# Altium schematic sheet nanoCH32V305.SchDoc
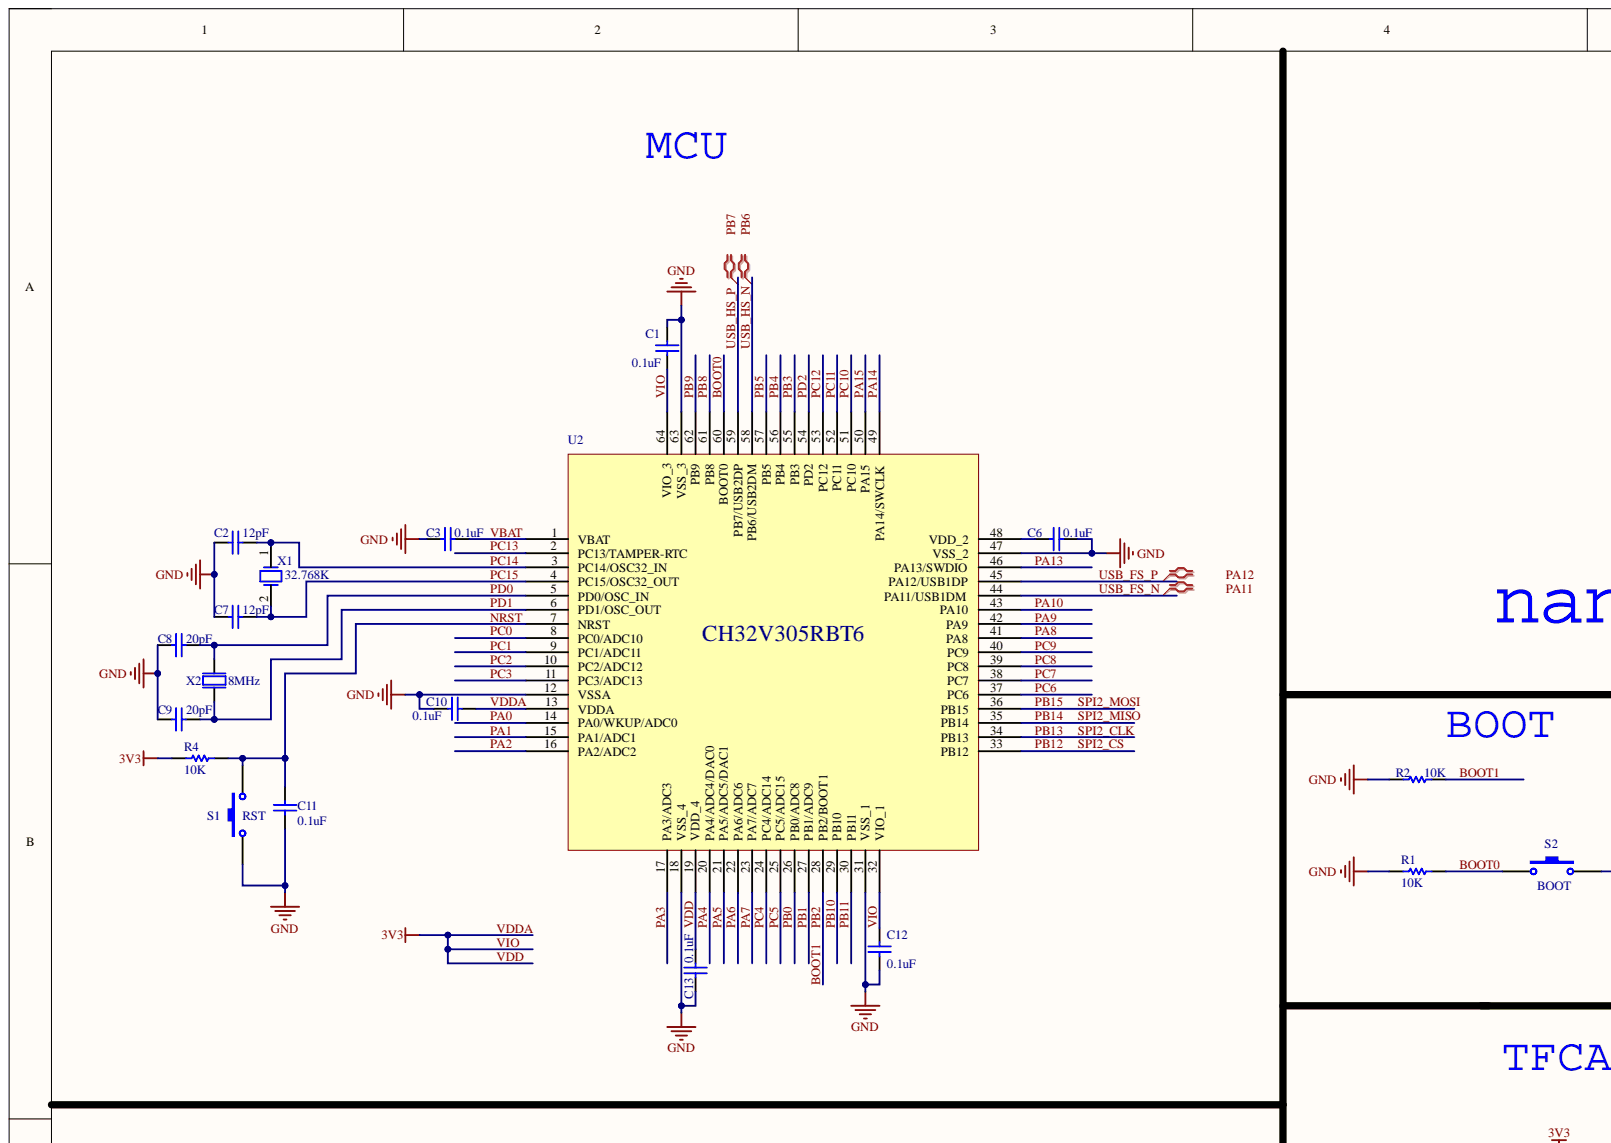
[diagram p0: nanoCH32V305.SchDoc, top left]
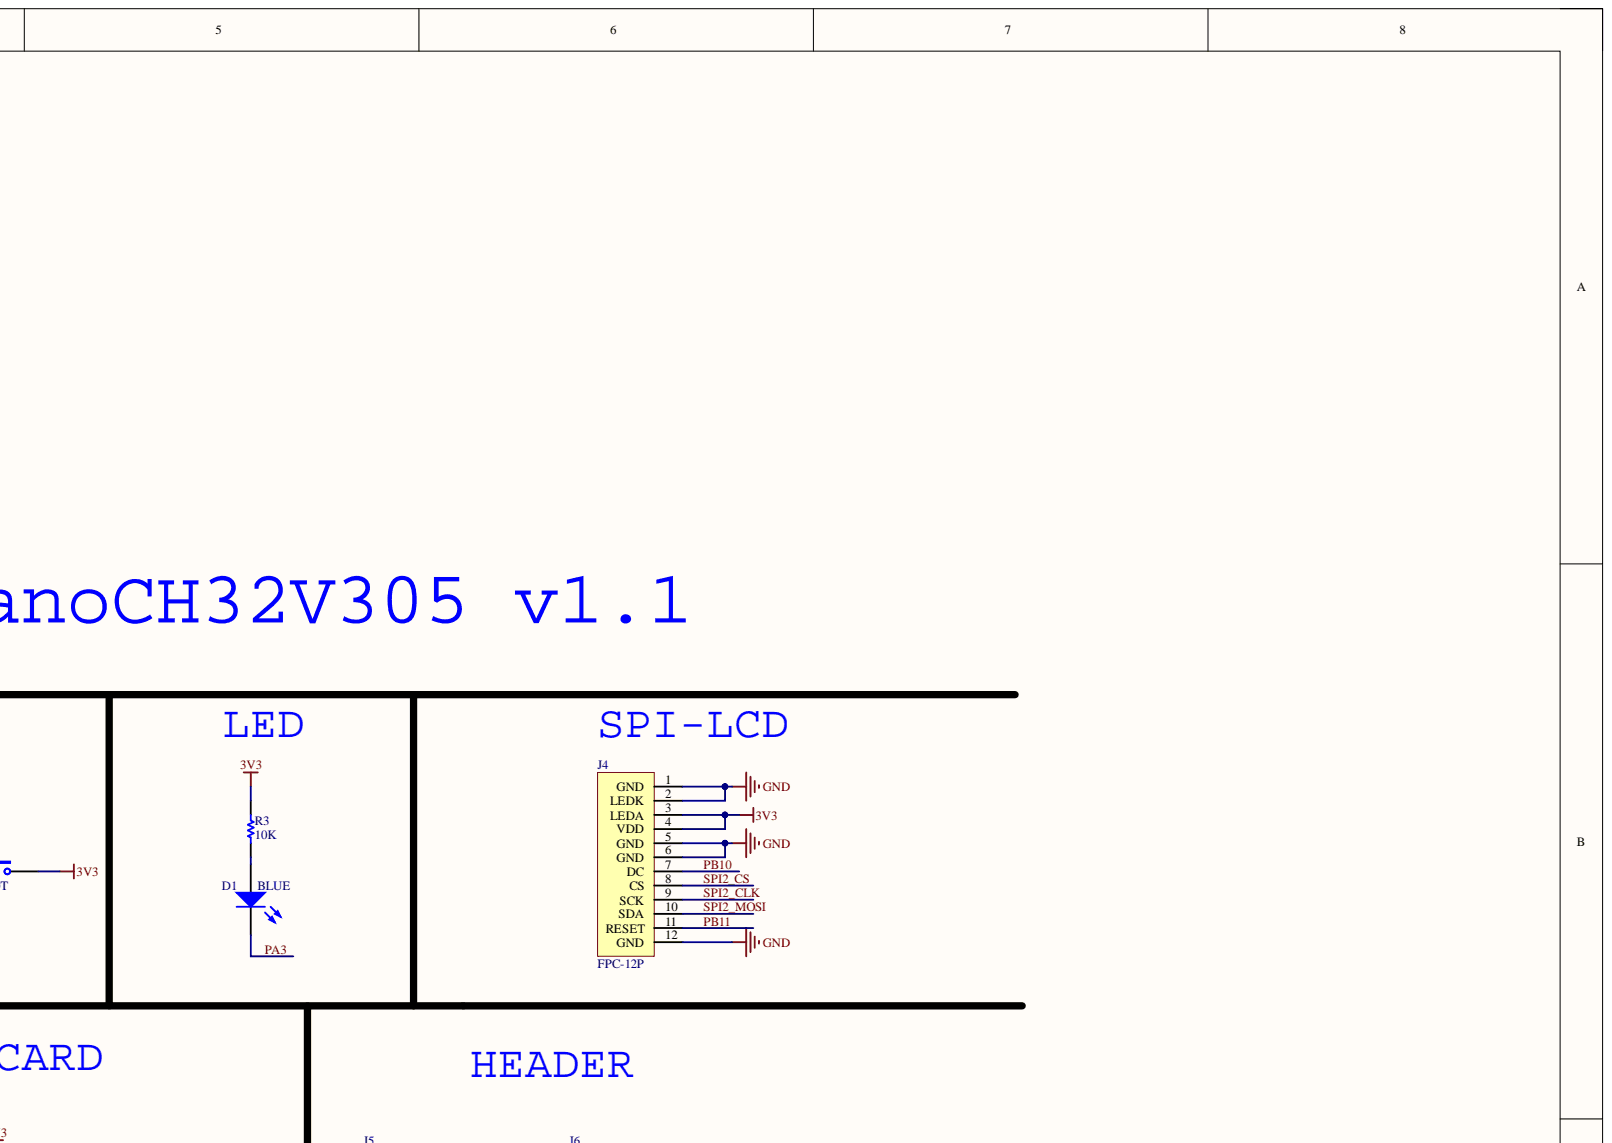
[diagram p1: nanoCH32V305.SchDoc, top right]
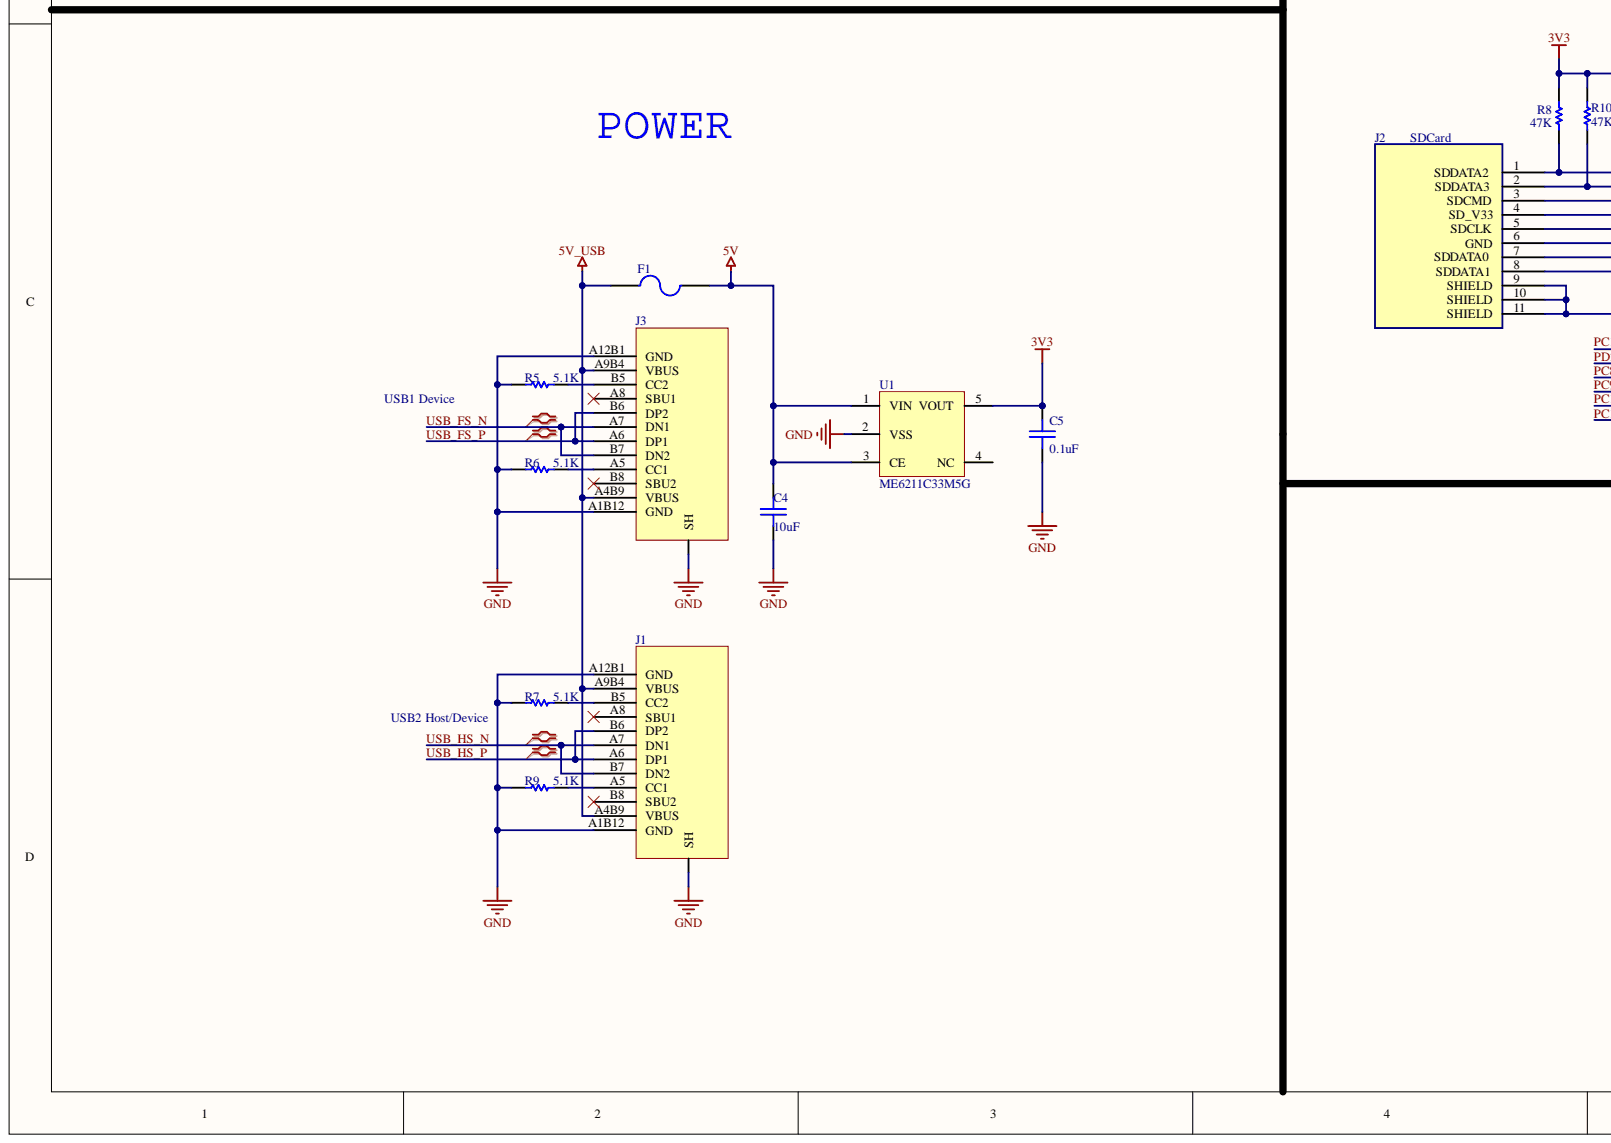
[diagram p2: nanoCH32V305.SchDoc, bottom left]
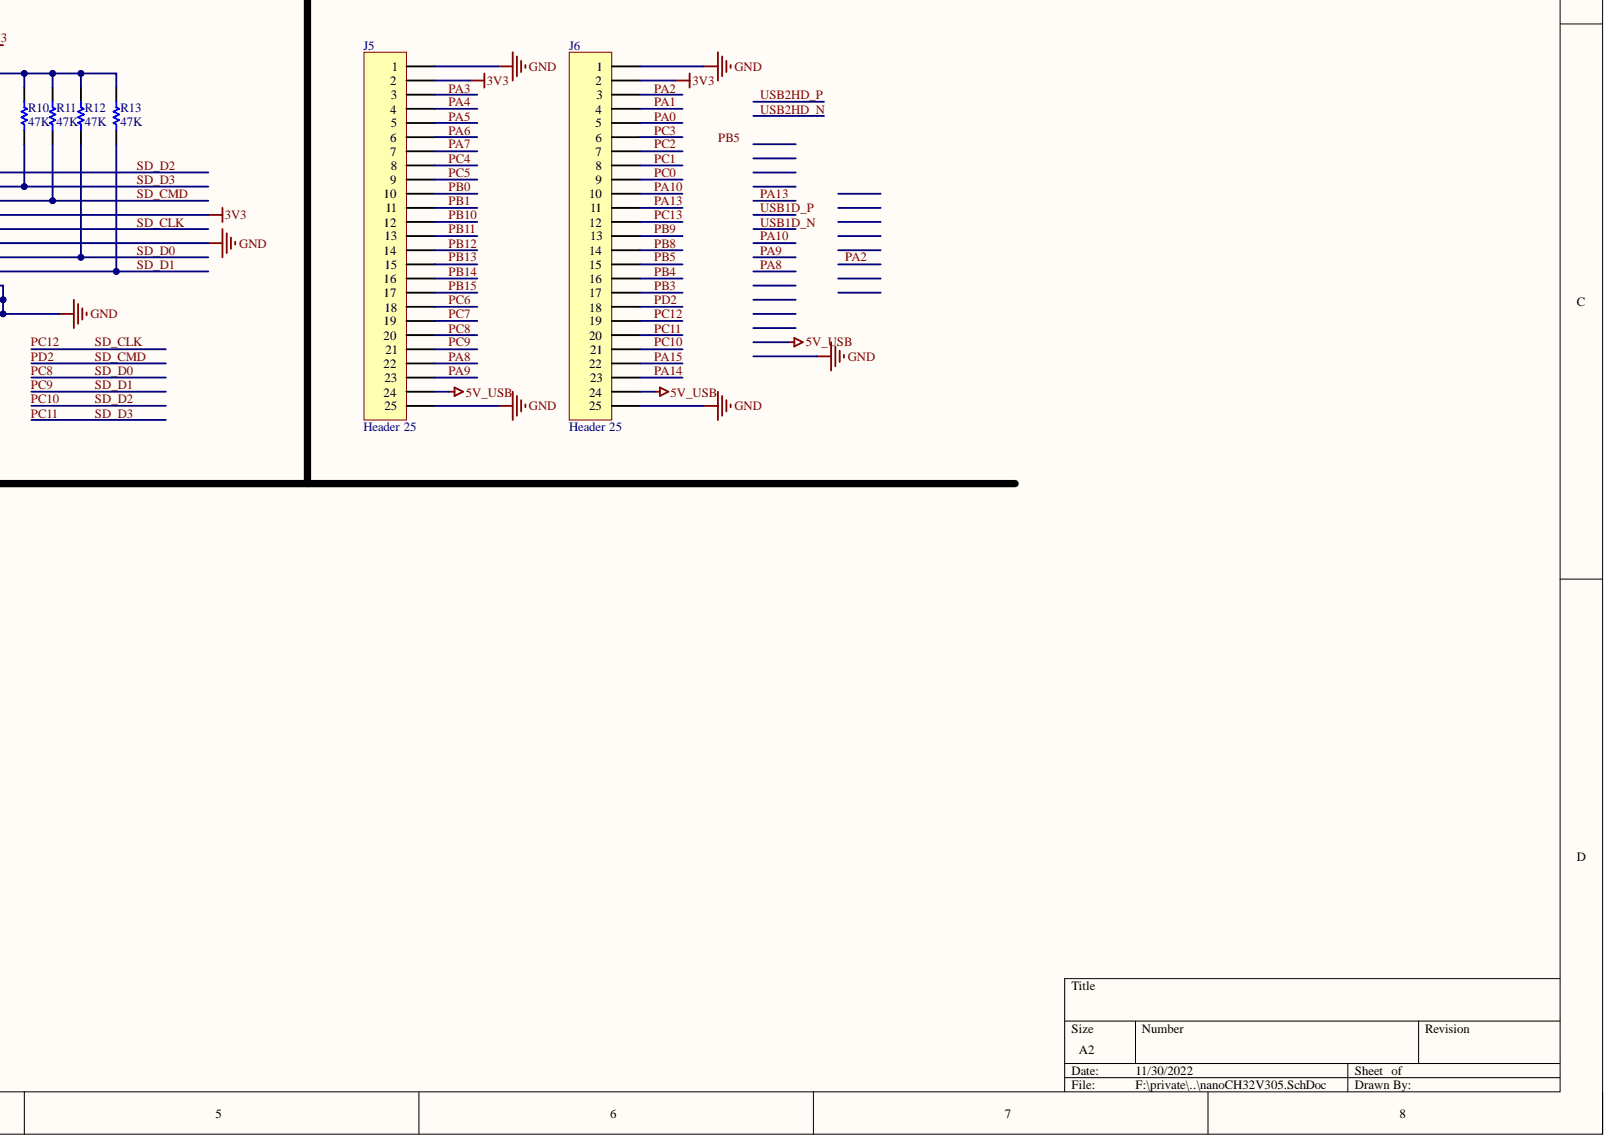
[diagram p3: nanoCH32V305.SchDoc, bottom right]

## Parts
C1  0.1uF  [C 0402_M_new]
C2  12pF  [C 0402_M_new]
C3  0.1uF  [C 0402_M_new]
C4  10uF  [C 0402_M_new]
C5  0.1uF  [C 0402_M_new]
C6  0.1uF  [C 0402_M_new]
C7  12pF  [C 0402_M_new]
C8  20pF  [C 0402_M_new]
C9  20pF  [C 0402_M_new]
C10  0.1uF  [C 0402_M_new]
C11  0.1uF  [C 0402_M_new]
C12  0.1uF  [C 0402_M_new]
C13  0.1uF  [C 0402_M_new]
D1  BLUE  [0603_LED_B]
F1  Fuse 2  [FU 0805]  (value not drawn)
J1  Type_C_16P  [Type_C_16P]  (value not drawn)
J2  SDCard  [SDCARD-1]
J3  Type_C_16P  [Type_C_16P]  (value not drawn)
J4  FPC-12P  [FPC0.5 2H-WS-12P]
J5  Header 25  [HEADER_25x1]
J6  Header 25  [HEADER_25x1]
R1  10K  [R 0402_M_new]
R2  10K  [R 0402_M_new]
R3  10K  [R 0402_M_new]
R4  10K  [R 0402_M_new]
R5  5.1K  [R 0402_M_new]
R6  5.1K  [R 0402_M_new]
R7  5.1K  [R 0402_M_new]
R8  47K  [R 0402_M_new]
R9  5.1K  [R 0402_M_new]
R10  47K  [R 0402_M_new]
R11  47K  [R 0402_M_new]
R12  47K  [R 0402_M_new]
R13  47K  [R 0402_M_new]
S1  RST  [SW-SMD_4P-L4.2-W3.3-P2.15-LS5.1]
S2  BOOT  [SW-SMD_4P-L4.2-W3.3-P2.15-LS5.1]
U1  ME6211C33M5G  [SOT23-5]
U2  CH32V305RBT6  [CH32V305RBT6]
X1  32.768K  [OSC 3215-2P]
X2  8MHz  [BT-XTAL_3225]

## Nets
5V: C4.1 F1.2 U1.1(VIN) U1.3(CE)
5V_USB: F1.1 J1.A4B9(VBUS) J1.A9B4(VBUS) J3.A4B9(VBUS) J3.A9B4(VBUS) J5.24 J6.24
GND: C1.1 C2.2 C3.2 C4.2 C5.2 C6.1 C7.2 C8.2 C9.2 C10.2 C11.1 C12.2 C13.2 J1.0(SH) J1.A1B12(GND) J1.A12B1(GND) J2.6(GND) J2.9(SHIELD) J2.10(SHIELD) J2.11(SHIELD) J3.0(SH) J3.A1B12(GND) J3.A12B1(GND) J4.1(GND) J4.2(LEDK) J4.5(GND) J4.6(GND) J4.12(GND) J5.1 J5.25 J6.1 J6.25 R1.1 R2.2 R5.1 R6.1 R7.1 R9.1 S1.1 U1.2(VSS) U2.12(VSSA) U2.18(VSS_4) U2.31(VSS_1) U2.47(VSS_2) U2.63(VSS_3) X2.2 X2.4
BOOT0: R1.2 S2.1 U2.60(BOOT0)
BOOT1: R2.1 U2.28(PB2/BOOT1)
NRST: C11.2 R4.1 S1.2 U2.7(NRST)
PA0: J6.5 U2.14(PA0/WKUP/ADC0)
PA1: J6.4 U2.15(PA1/ADC1)
PA2: J6.3 U2.16(PA2/ADC2)
PA3: D1.2 J5.3 U2.17(PA3/ADC3)
PA4: J5.4 U2.20(PA4/ADC4/DAC0)
PA5: J5.5 U2.21(PA5/ADC5/DAC1)
PA6: J5.6 U2.22(PA6/ADC6)
PA7: J5.7 U2.23(PA7/ADC7)
PA8: J5.22 U2.41(PA8)
PA9: J5.23 U2.42(PA9)
PA10: J6.10 U2.43(PA10)
PA13: J6.11 U2.46(PA13/SWDIO)
PA14: J6.23 U2.49(PA14/SWCLK)
PA15: J6.22 U2.50(PA15)
PB0: J5.10 U2.26(PB0/ADC8)
PB1: J5.11 U2.27(PB1/ADC9)
PB3: J6.17 U2.55(PB3)
PB4: J6.16 U2.56(PB4)
PB5: J6.15 U2.57(PB5)
PB8: J6.14 U2.61(PB8)
PB9: J6.13 U2.62(PB9)
PB10: J4.7(DC) J5.12 U2.29(PB10)
PB11: J4.11(RESET) J5.13 U2.30(PB11)
PB12: J4.8(CS) J5.14 U2.33(PB12)
PB13: J4.9(SCK) J5.15 U2.34(PB13)
PB14: J5.16 U2.35(PB14)
PB15: J4.10(SDA) J5.17 U2.36(PB15)
PC0: J6.9 U2.8(PC0/ADC10)
PC1: J6.8 U2.9(PC1/ADC11)
PC2: J6.7 U2.10(PC2/ADC12)
PC3: J6.6 U2.11(PC3/ADC13)
PC4: J5.8 U2.24(PC4/ADC14)
PC5: J5.9 U2.25(PC5/ADC15)
PC6: J5.18 U2.37(PC6)
PC7: J5.19 U2.38(PC7)
PC8: J2.7(SDDATA0) J5.20 R12.2 U2.39(PC8)
PC9: J2.8(SDDATA1) J5.21 R13.2 U2.40(PC9)
PC10: J2.1(SDDATA2) J6.21 R8.2 U2.51(PC10)
PC11: J2.2(SDDATA3) J6.20 R10.2 U2.52(PC11)
PC12: J2.5(SDCLK) J6.19 U2.53(PC12)
PC13: J6.12 U2.2(PC13/TAMPER-RTC)
PC14: C2.1 U2.3(PC14/OSC32_IN) X1.1
PC15: C7.1 U2.4(PC15/OSC32_OUT) X1.2
PD0: C8.1 U2.5(PD0/OSC_IN) X2.1
PD1: C9.1 U2.6(PD1/OSC_OUT) X2.3
PD2: J2.3(SDCMD) J6.18 R11.2 U2.54(PD2)
USB_FS_N: J3.A7(DN1) J3.B7(DN2) U2.44(PA11/USB1DM)
USB_FS_P: J3.A6(DP1) J3.B6(DP2) U2.45(PA12/USB1DP)
USB_HS_N: J1.A7(DN1) J1.B7(DN2) U2.58(PB6/USB2DM)
USB_HS_P: J1.A6(DP1) J1.B6(DP2) U2.59(PB7/USB2DP)
VBAT: C3.1 U2.1(VBAT)
VDD: C1.2 C5.1 C10.1 C12.1 C13.1 J2.4(SD_V33) J4.3(LEDA) J4.4(VDD) J5.2 J6.2 R3.1 R4.2 R8.1 R10.1 R11.1 R12.1 R13.1 S2.2 U1.5(VOUT) U2.13(VDDA) U2.19(VDD_4) U2.32(VIO_1) U2.64(VIO_3)
NetC6_2: C6.2 U2.48(VDD_2)
NetD1_1: D1.1 R3.2
NetJ1_A5: J1.A5(CC1) R9.2
NetJ1_B5: J1.B5(CC2) R7.2
NetJ3_A5: J3.A5(CC1) R6.2
NetJ3_B5: J3.B5(CC2) R5.2
PA11:
PA12:
PB6:
PB7:
USB1D_N:
USB1D_P:
USB2HD_N:
USB2HD_P:

Not connected: J1 A8(SBU1) B8(SBU2); J3 A8(SBU1) B8(SBU2); U1 4(NC)

## Library data of the parts
C1-C3 C6-C13 = C0603: Capacitor; Component Kind: Standard; LatestRevisionDate: 17-Jul-2002; LatestRevisionNote: Re-released for DXP Platform.; Library Name: MYLIB.IntLib; PackageDescription: Radial Cap, Thru-Hole; 2 Leads; 0.3 in Pin Spacing; PackageReference: RAD-0.3; Published: 8-Jun-2000; Signal Integrity: Cap; Simulation: CAP; Publisher: Altium Limited
  C1 C3 C6 C10-C13: Value: 0.1uF
  C2 C7: Value: 12pF
  C8 C9: Value: 20pF
C4 = SMT_C_0805: Surface mount capacitor 0805; Component Kind: Standard; Library Name: Vowstar_Basic_Device.IntLib
C5 = SMT_C_0402: Surface mount capacitor 0402; Component Kind: Standard; Library Name: Vowstar_Basic_Device.IntLib
D1 = D0603: Typical RED, GREEN, YELLOW, AMBER GaAs LED; Component Kind: Standard; LatestRevisionDate: 17-Jul-2002; LatestRevisionNote: Re-released for DXP Platform.; Library Name: MYLIB.IntLib; PackageDescription: SM LED; 2 Flat Leads; PackageReference: 3.2X1.6X1.1; PackageVersion: Feb-2002; Published: 8-Jun-2000; Simulation: LED2; Publisher: Altium Limited
F1 = Fuse 2: Fuse; Component Kind: Standard; LatestRevisionDate: 17-Jul-2002; LatestRevisionNote: Re-released for DXP Platform.; Library Name: Miscellaneous Devices.IntLib; PackageDescription: Thru-Hole; 2 Leads; PackageReference: PIN-W2/E2.8; Published: 8-Jun-2000; Simulation: FUSE; Publisher: Altium Limited
R1-R13 = R0603: Resistor; Component Kind: Standard; LatestRevisionDate: 17-Jul-2002; LatestRevisionNote: Re-released for DXP Platform.; Library Name: MYLIB.IntLib; PackageDescription: Axial Device, Thru-Hole; 2 Leads; 0.3 in Pin Spacing; PackageReference: AXIAL-0.3; Published: 8-Jun-2000; Signal Integrity: Res; Simulation: RESISTOR; Publisher: Altium Limited
  R1-R4: Value: 10K
  R5-R7 R9: Value: 5.1K
  R8 R10-R13: Value: 47K
S1 S2 = SW-PB: Switch; Component Kind: Standard; LatestRevisionDate: 17-Jul-2002; LatestRevisionNote: Re-released for DXP Platform.; Library Name: Miscellaneous Devices.IntLib; PackageDescription: Switch; 2 Leads; PackageReference: SPST-2; Published: 24-Mar-1999; Publisher: Altium Limited
X1 = XTAL: Component Kind: Standard; Library Name: nanoCH32V103.SCHLIB
X2 = XTAL SMD 12MHz (3225): XTAL SMD 3225, 8MHz, 18pF, ±30ppm; 2nd source part number: 184-2056; Manufacturer: TXC Corporation; Manufacturer Part Number: 7M-12.000MAAJ-T; Artikkelnr Noca: 22019166; Artikkelnr Simpro: N.A.; Database Table Name: Crystal_Osc$; Note: XTAL SMD 12.000MHz; 2nd source Supplier: Farnell; Component Kind: Standard; Datasheet: http://www.txccrystal.com/images/pdf/7m.pdf; Footprint Doc: BT-XTAL_3225; LatestRevisionDate: 2010-08-31; Library Name: Nordic Discrete DB.DbLib; Part Number: XTAL SMD 12MHz (3225); Pin Count: 4; Published: 2010-08-31; Supplier: Digi-Key; Supplier part number: 887-1121-1-ND; Value: 8MHz; Publisher: Nordic Semiconductor
J1 J3 = Type_C_16P: Component Kind: Standard; Library Name: ICESugar.SCHLIB
J2 = SDCard: Component Kind: Standard; Library Name: ICESugar-Pro.SCHLIB
J4 = FPC-12P: Component Kind: Standard; Library Name: nanoCH32V203.SCHLIB
J5 J6 = Header 25: Component Kind: Standard; Library Name: nanoCH32V305.SCHLIB
U1 = ME6211C33M5G: Component Kind: Standard
U2 = CH32V305RBT6: Component Kind: Standard; Library Name: nanoCH32V305.SCHLIB
